# Altium schematic sheet HVBlocks.SchDoc
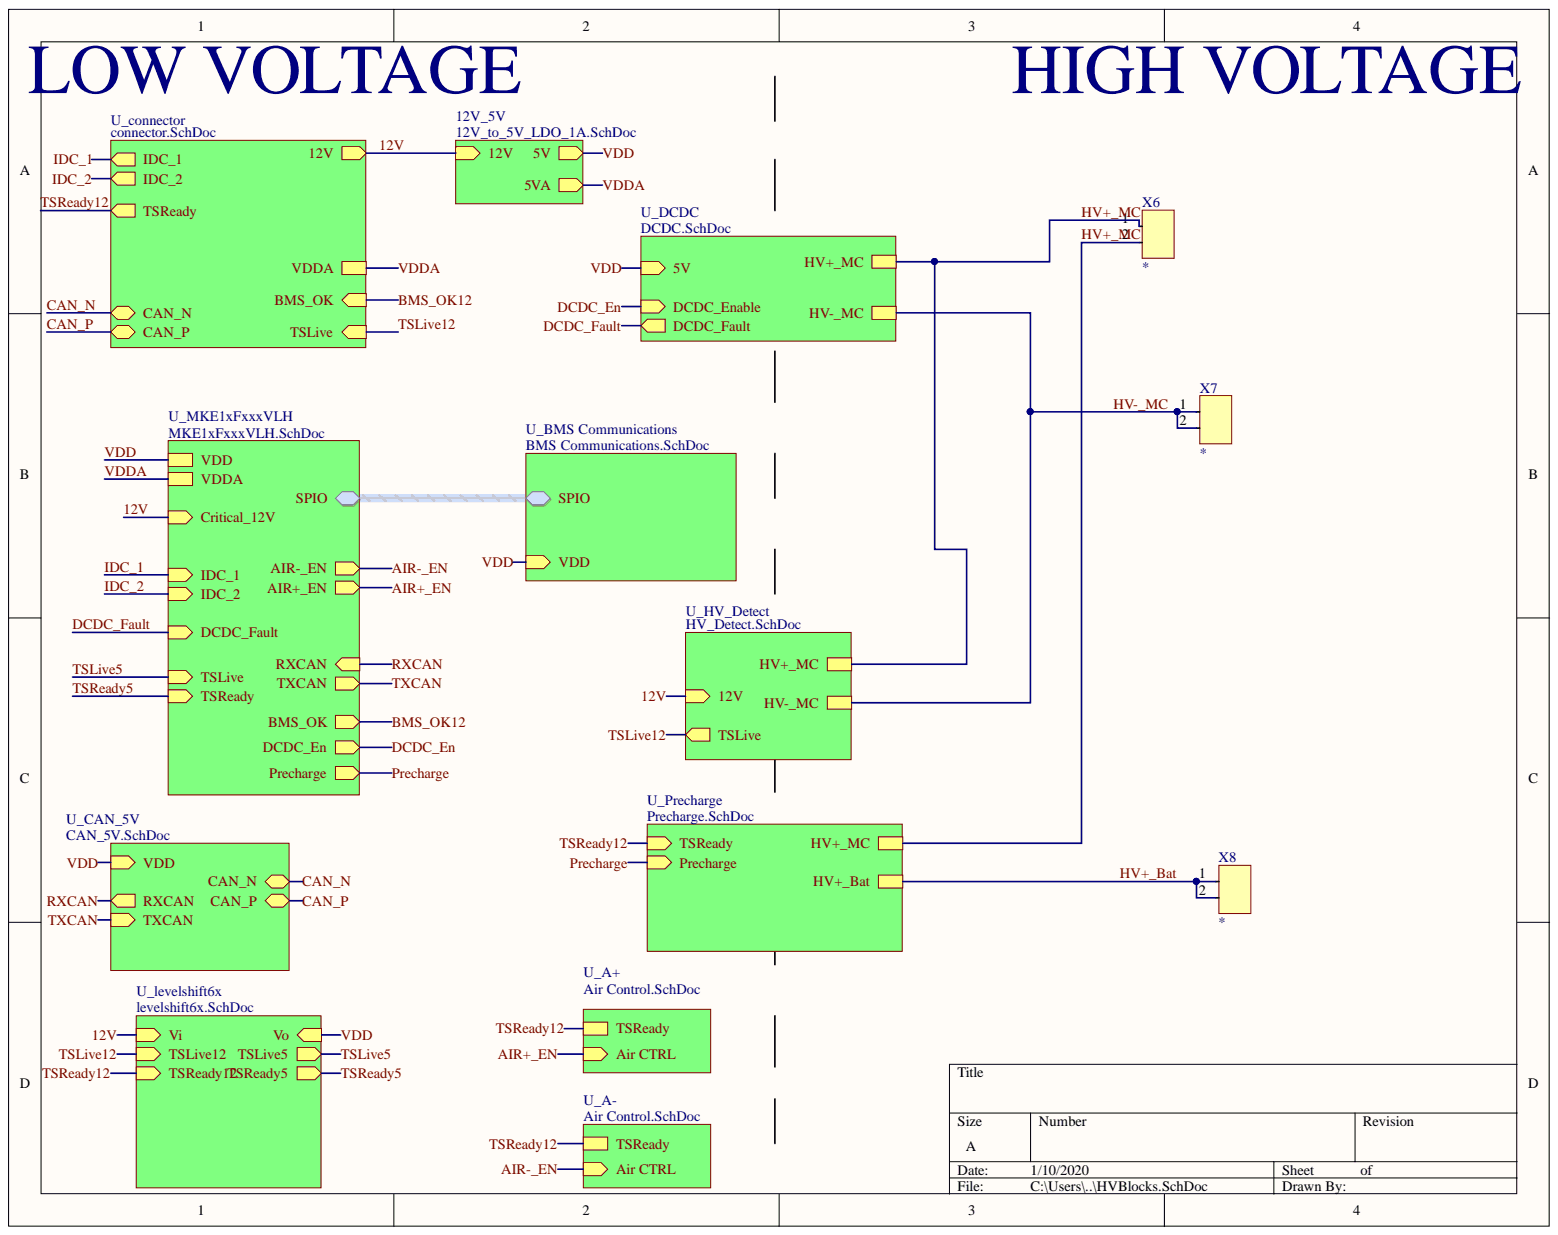
[diagram p0: HVBlocks.SchDoc]

## Parts
X6  (no value)  [CONN 43650-0200 MOLEX 2PIN]
X7  (no value)  [CONN 43650-0200 MOLEX 2PIN]
X8  (no value)  [CONN 43650-0200 MOLEX 2PIN]

## Nets
HV+_Bat: X8.1 X8.2
HV+_MC: X6.1 X6.2
HV-_MC: X7.1 X7.2
12V:
AIR+_EN:
AIR-_EN:
BMS_OK12:
CAN_N:
CAN_P:
DCDC_En:
DCDC_Fault:
IDC_1:
IDC_2:
Precharge:
RXCAN:
SPIO.CS:
SPIO.MISO:
SPIO.MOSI:
SPIO.SCK:
TSLive5:
TSLive12:
TSReady5:
TSReady12:
TXCAN:
VDD:
VDDA:

## Library data of the parts
X6-X8 = 9: Molex 43650_0200; Database Table Name: connectors; name: 0436500200; numcontacts: 2; numrows: 1; pitch: 3 mm; Component Kind: Standard; id: 9; link: https://www.digikey.com/product-detail/en/molex-llc/0436500200/WM1860-ND/268989; quantity: 0; Library Name: altlib.DbLib
